# Altium schematic sheet IR_Sensor.SchDoc
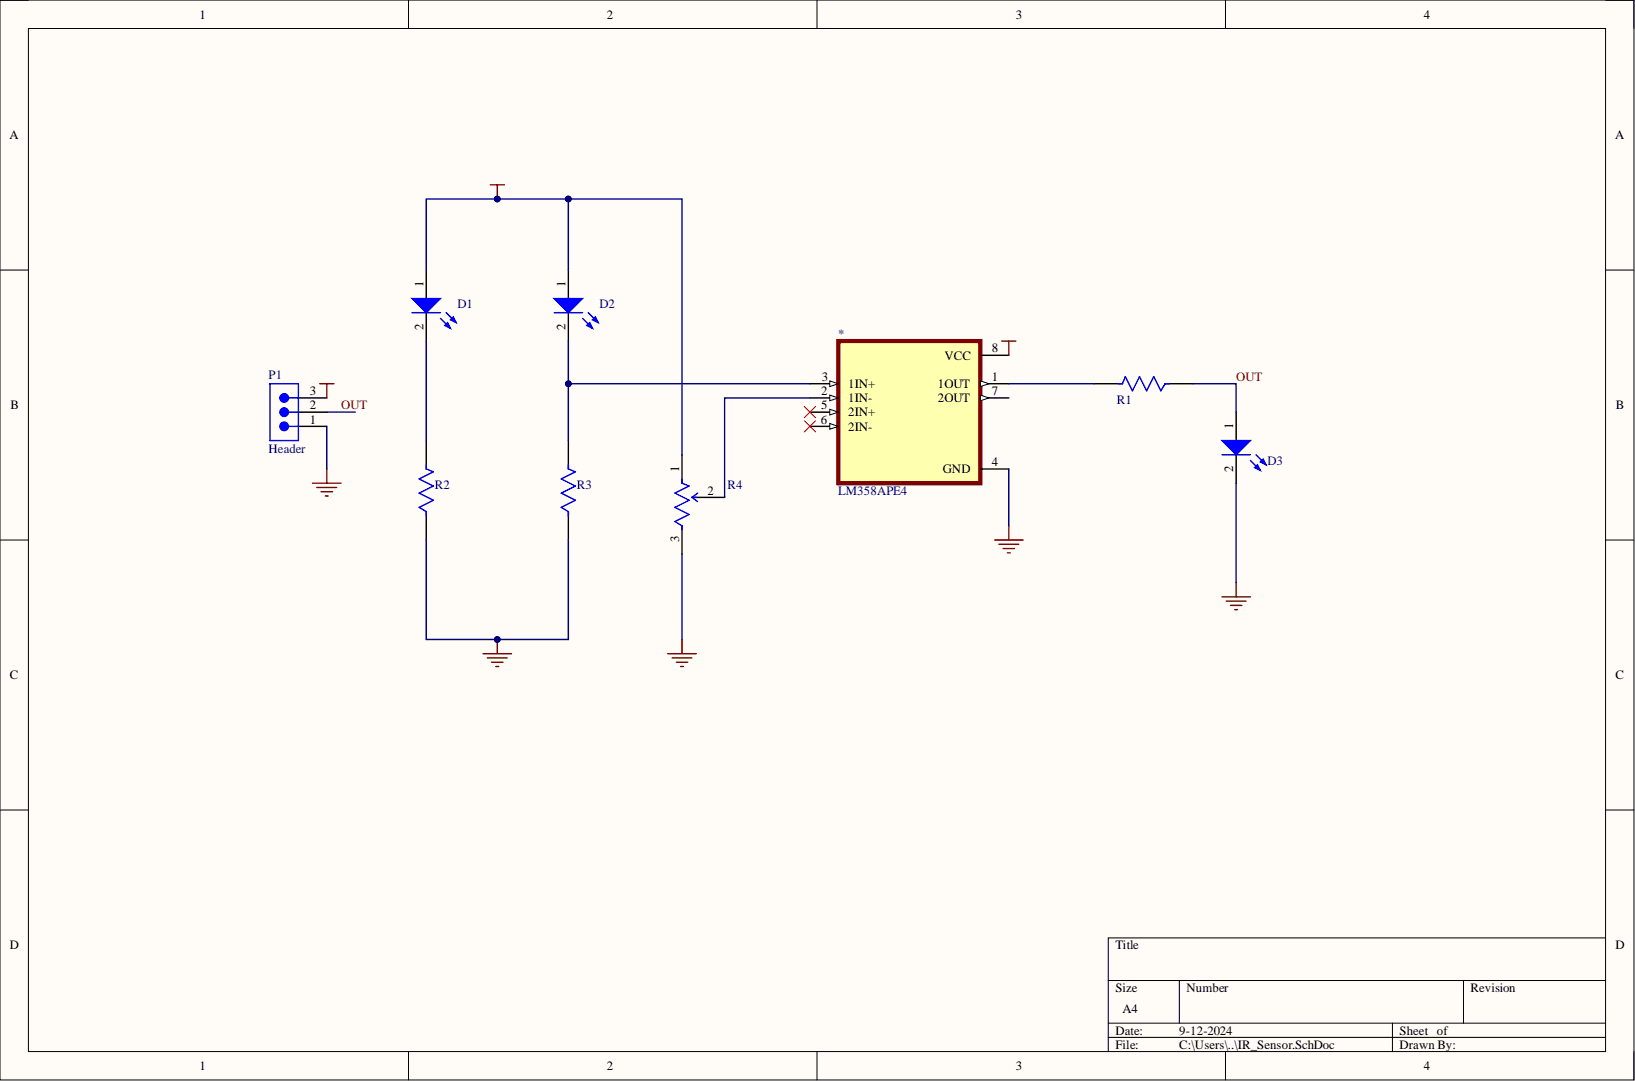
[diagram p0: IR_Sensor.SchDoc]

## Parts
*  LM358APE4  [DIP794W45P254L959H508Q8]
D1  151051BS04000  [WL-TMRC_D5.9H8.7]  (value not drawn)
D2  151051BS04000  [WL-TMRC_D5.9H8.7]  (value not drawn)
D3  151051BS04000  [WL-TMRC_D5.9H8.7]  (value not drawn)
P1  Header  [679303124022]
R1  MRS25000C1002FCT00  [FP-MRS25-MFG]  (value not drawn)
R2  MRS25000C1002FCT00  [FP-MRS25-MFG]  (value not drawn)
R3  MRS25000C1002FCT00  [FP-MRS25-MFG]  (value not drawn)
R4  3386P-1-104LF  [FP-3386P-MFG]  (value not drawn)

## Nets
+5: *.8(VCC) D1.1 D2.1 P1.3 R4.1
GND: *.4(GND) D3.2 P1.1 R2.1 R3.1 R4.3
OUT: D3.1 P1.2 R1.1
Net*_1: *.1(1OUT) R1.2
Net*_2: *.2(1IN-) R4.2
Net*_3: *.3(1IN+) D2.2 R3.2
NetD1_2: D1.2 R2.2

Not connected: * 5(2IN+) 6(2IN-) 7(2OUT)

## Library data of the parts
* = LM358APE4: Operational Amplifier, 2 Func, 5000uV Offset-Max, BIPolar, PDIP8; Manufacturer: Texas Instruments; Architecture: VOLTAGE-FEEDBACK; Average Bias Current-Max (IIB): -200nA; Bias Current-Max (IIB) @25C: 100nA; Channels: 2; Common-mode Reject Ratio-Nom: 80dB; Frequency Compensation: YES; Input Offset Voltage-Max: 5mV; Low-Offset: No; Max Supply Current: 2mA; Nominal Supply Voltage: 5V; Package Body Material: Plastic; Package Equivalence Code: DIP8,.3; Power Supplies: +-1.5/+-15/3/30V; Qualification Status: Not Qualified; Slew Rate: 0.3V/µs; Supply Voltage Limit-Max: 32V; Surface Mount: No; Unity Gain BW-Nom: 700kHz; Voltage Gain-Min: 15000; Amplifier Type: OPERATIONAL AMPLIFIER; Case/Package: DIP; Category: Amplifiers - Op Amps, Buffer, Instrumentation; Component Kind: Standard; ComponentLink1Description: https://www.ti.com:443/lit/ds/symlink/lm158.pdf?src-supplier=IHS+Markit; ComponentLink1URL: https://www.ti.com:443/lit/ds/symlink/lm158.pdf?src-supplier=IHS+Markit; ComponentLink2Description: https://datasheet.ciiva.com/1811/lm358-1811016.pdf?src-supplier=Digikey; ComponentLink2URL: https://datasheet.ciiva.com/1811/lm358-1811016.pdf?src-supplier=Digikey; Height - Seated (Max): 5.08mm; JESD-30 Code: R-PDIP-T8; JESD-609 Code: e3; Length: 9.59mm; Library Name: Schlib.SchLib; Manufacturer Part Number: LM358APE4; Max Operating Temperature: 70°C; Min Operating Temperature: 0°C; Moisture Sensitivity Level: 1; Package Shape: Rectangular; Package Style: IN-LINEMeter; Packaging: Rail/Tube; Peak Reflow Temperature (Cel): 260°C; Pins: 8; Pricing: 1000=0.1345, 2000=0.1331, 3000=0.1318, 4000=0.1305, 5000=0.1292, 6000=0.1279, 10000=0.1266, 20000=0.1253, 50000=0.1241 (USD); Reach SVHC Compliant: Yes; RoHS: Yes; RoHS Compliant: Yes; Supplier: Arrow Electronics; Supplier Part Number: LM358APE4; Technology: BIPOLAR; Temperature Grade: COMMERCIAL; Terminal Finish: Tin; Terminal Form: Through Hole; Terminal Pitch: 2.54mm; Terminal Position: DUAL; Width: 7.62mm
D1-D3 = CMP-1488-00009-2: NexarPartId: 29717812; Color: Blue; Dominant Wavelength: 470nm; Forward Current: 100mA; Forward Voltage: 3.2V; Lens Size: 5mm; Lens Style: Circular, Diffused, Round; Luminous Intensity: 500mcd; Max Reverse Voltage (DC): 5V; Peak Wavelength: 465nm; Test Current: 20mA; Viewing Angle: 40°; Case/Package: Radial; Component Kind: Standard; Height: 8.6mm; Length: 5mm; Library Name: Altium Content Vault; Max Operating Temperature: 85°C; Min Operating Temperature: -40°C; Mount: Through Hole; Packaging: Bulk; REACH SVHC: Unknown; RoHS: Compliant; Wavelength: 470nm; Width: 5mm
P1 = CMP-1502-00722-2: NexarPartId: 18818282; Connector Type: Male Pin; Contact Resistance: 20mΩ; Current Rating: 1A; Gender: Male; Insulation: Insulated; Insulation Resistance: 100MΩ; Number of Contacts: 3; Operating Supply Voltage: 50V; Pitch: 1.5mm; Color: Beige, White; Component Kind: Standard; Contact Gender: Male; Contact Material: Copper; Contact Plating: Tin; Flammability Rating: UL94 V-0; Height: 5mm; Housing Material: Nylon; Lead Pitch: 1.5mm; Length: 7.5mm; Library Name: Altium Content Vault; Max Operating Temperature: 85°C; Min Operating Temperature: -25°C; Mount: Surface Mount; Number of Pins: 3; Number of Positions: 3; Number of Rows: 1; Orientation: Vertical; Packaging: Tape and Reel; REACH SVHC: No SVHC; RoHS: Compliant; Termination: SMD/SMT; Voltage Rating: 50V; Width: 7.5mm
R1-R3 = CMP-00030-42632392-1: MRS25000C1002FCT00 Resistor, 10 kOhm, ± 1%, 600 mW, Axial Leaded, Metal Film (Thin Film), Professional; Lead Length: 26mm; Max Power Dissipation: 600mW; Resistance: 10kΩ; Temperature Coefficient: 50ppm/°C; Voltage Rating: 350V; Case/Package: Conformal; Component Kind: Standard; Composition: Metal Film, Thin Film; Contact Plating: Tin; Diameter: 2.5mm; Lead Free: Lead Free; Length: 6.5mm; Library Name: Altium Content Vault; Max Operating Temperature: 155°C; Military Standard: Not; Min Operating Temperature: -55°C; Mount: Through Hole; NexarPartId: 42632392; Number of Pins: 2; Number of Terminations: 2; Power Rating: 600mW; Radiation Hardening: No; REACH SVHC: Unknown; RoHS: Compliant; Schedule B: 8533210090, 8533210090; Termination: Axial; Tolerance: 1%; Voltage Rating (DC): 350V; Width: 2.5mm
R4 = CMP-2000-05592-8: TRIMMER 100K OHM 0.5W PC PIN TOP; Manufacturer Series: 3386; Actuator Orientation: Vertical; Actuator Type: SCREW; Max Power Dissipation: 500mW; Number of Terminals/Pins: 3; Number of Turns: 1; Resistance: 100kR; Resistance Law: Linear; Resistance Tolerance: 10%; Temperature Coefficient: 100ppm/°C; Voltage Rating: 300V; Color: Blue; Component Kind: Standard; Contact Plating: Tin; Depth: 9.53mm; Height: 4.83mm; Lead Free: Contains Lead; Length: 9.53mm; Library Name: Altium Content Vault; Max Operating Temperature: 125°C; Military Standard: Not; Min Operating Temperature: -55°C; Mount: Through Hole; Number of Pins: 3; Package Quantity: 100; Packaging: Rail/Tube; Power Rating: 500mW; Radiation Hardening: No; Reach SVHC Compliant: Yes; Resistor Type: Cermet; RoHS Compliant: Yes; Series: Trimpot® 3386 - Sealed; Taper: Linear; Technology: CERMET; Terminal Finish: Tin; Termination: Pin; Tolerance: 10%; Type: Potentiometer; Weight: 0.03oz; Width: 9.53mm
